# Altium schematic sheet Sheet1.SchDoc
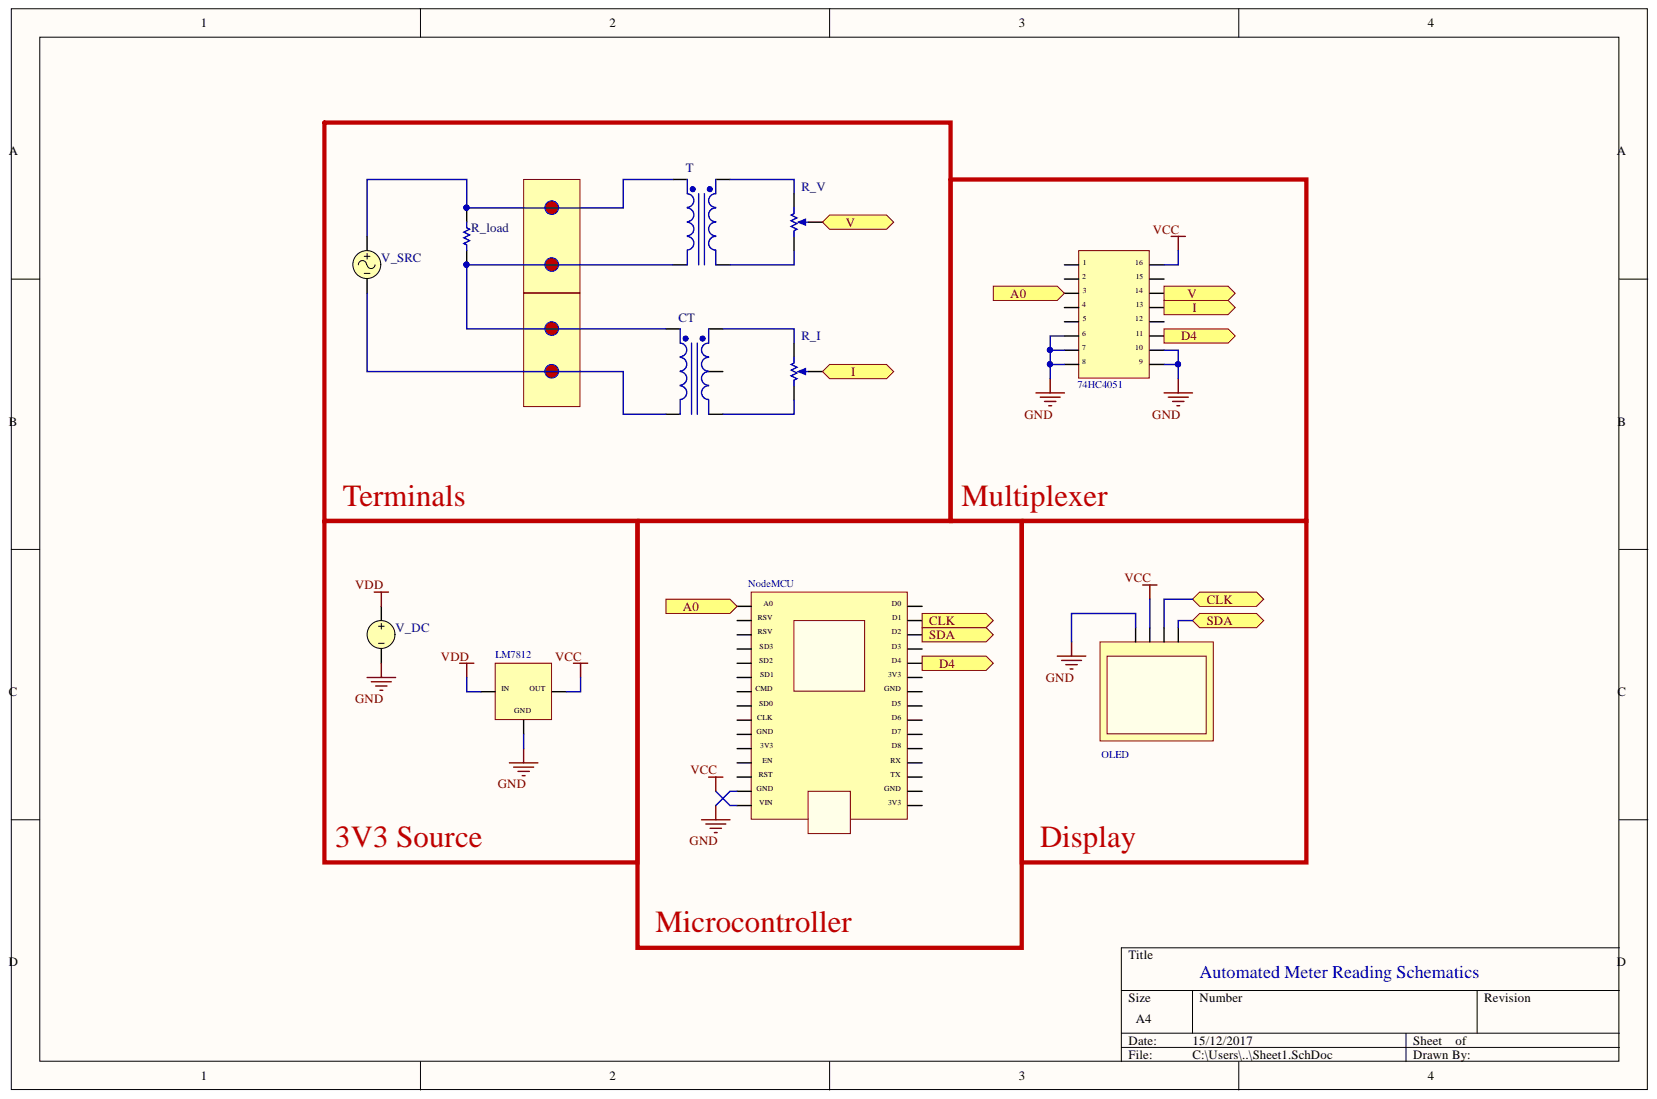
[diagram p0: Sheet1.SchDoc]

## Parts
CT  Trans CT Ideal  [TRF_5]  (value not drawn)
R_I  RPot  [VR5]  (value not drawn)
R_load  Res1  [AXIAL-0.3]  (value not drawn)
R_V  RPot  [VR5]  (value not drawn)
T  Trans Ideal  [TRF_4]  (value not drawn)
V_DC  VSRC  (value not drawn)
V_SRC  VSIN  (value not drawn)

## Ports
A0, CLK, D4, I, SDA, V

## Nets
GND: V_DC.2
VDD: V_DC.1
I: R_I.3 ; port I
V: R_V.3 ; port V
NetCT_1: CT.1 R_load.1 T.2
NetCT_2: CT.2 V_SRC.2
NetCT_3: CT.3 R_I.1
NetCT_5: CT.5 R_I.2
NetR_load_2: R_load.2 T.1 V_SRC.1
NetR_V_1: R_V.1 T.3
NetR_V_2: R_V.2 T.4
N00002: ; port SDA
N00003: ; port CLK
N00004: ; port D4
N00007: ; port A0
VCC:

Not connected: CT 4

## Library data of the parts
CT = Trans CT Ideal: Center-Tapped Transformer (Ideal); Component Kind: Standard; LatestRevisionDate: 17-Jul-2002; LatestRevisionNote: Re-released for DXP Platform.; Library Name: Miscellaneous Devices.IntLib; PackageDescription: Transformer; 5 Leads; PackageReference: TRF_5; Published: 8-Jun-2000; Simulation: IDEALTRANSCT; Publisher: Altium Limited
R_I R_V = RPot: Potentiometer; Set Position: 0.5; Sim Note: Enter the Resistance value and the set point into parameter fields.; Value: 1K; Component Kind: Standard; LatestRevisionDate: 17-Jul-2002; LatestRevisionNote: Re-released for DXP Platform.; Library Name: Miscellaneous Devices.IntLib; PackageDescription: Variable Resistor, Thru-Hole; 3 Leads; PackageReference: VR5; Published: 8-Jun-2000; Simulation: POT; Publisher: Altium Limited
R_load = Res1: Resistor; Value: 1K; Component Kind: Standard; LatestRevisionDate: 17-Jul-2002; LatestRevisionNote: Re-released for DXP Platform.; Library Name: Miscellaneous Devices.IntLib; PackageDescription: Axial Device, Thru-Hole; 2 Leads; 0.3 in Pin Spacing; PackageReference: AXIAL-0.3; Published: 8-Jun-2000; Signal Integrity: Res; Simulation: RESISTOR; Publisher: Altium Limited
T = Trans Ideal: Transformer (Ideal); Component Kind: Standard; LatestRevisionDate: 17-Jul-2002; LatestRevisionNote: Re-released for DXP Platform.; Library Name: Miscellaneous Devices.IntLib; PackageDescription: Transformer; 4 Leads; PackageReference: TRF_4; Published: 8-Jun-2000; Simulation: IDEALTRANS; Publisher: Altium Limited
V_DC = VSRC: Voltage Source; Note: PCB Footprint - Not required; Component Kind: Standard; LatestRevisionDate: 17-Jul-2002; LatestRevisionNote: Re-released for DXP Platform.; Library Name: Simulation Sources.IntLib; PackageReference: Not Applicable; Published: 8-Jun-2000; Simulation: VSRC; Publisher: Altium Limited
V_SRC = VSIN: Sinusoidal Voltage Source; Note: PCB Footprint - Not required; Component Kind: Standard; LatestRevisionDate: 15-Nov-2004; LatestRevisionNote: Corrected Netlist.; Library Name: Simulation Sources.IntLib; PackageReference: Not Applicable; Published: 8-Jun-2000; Simulation: VSIN; Publisher: Altium Limited
